# Altium schematic sheet Peripherals.SchDoc(Peripherals)
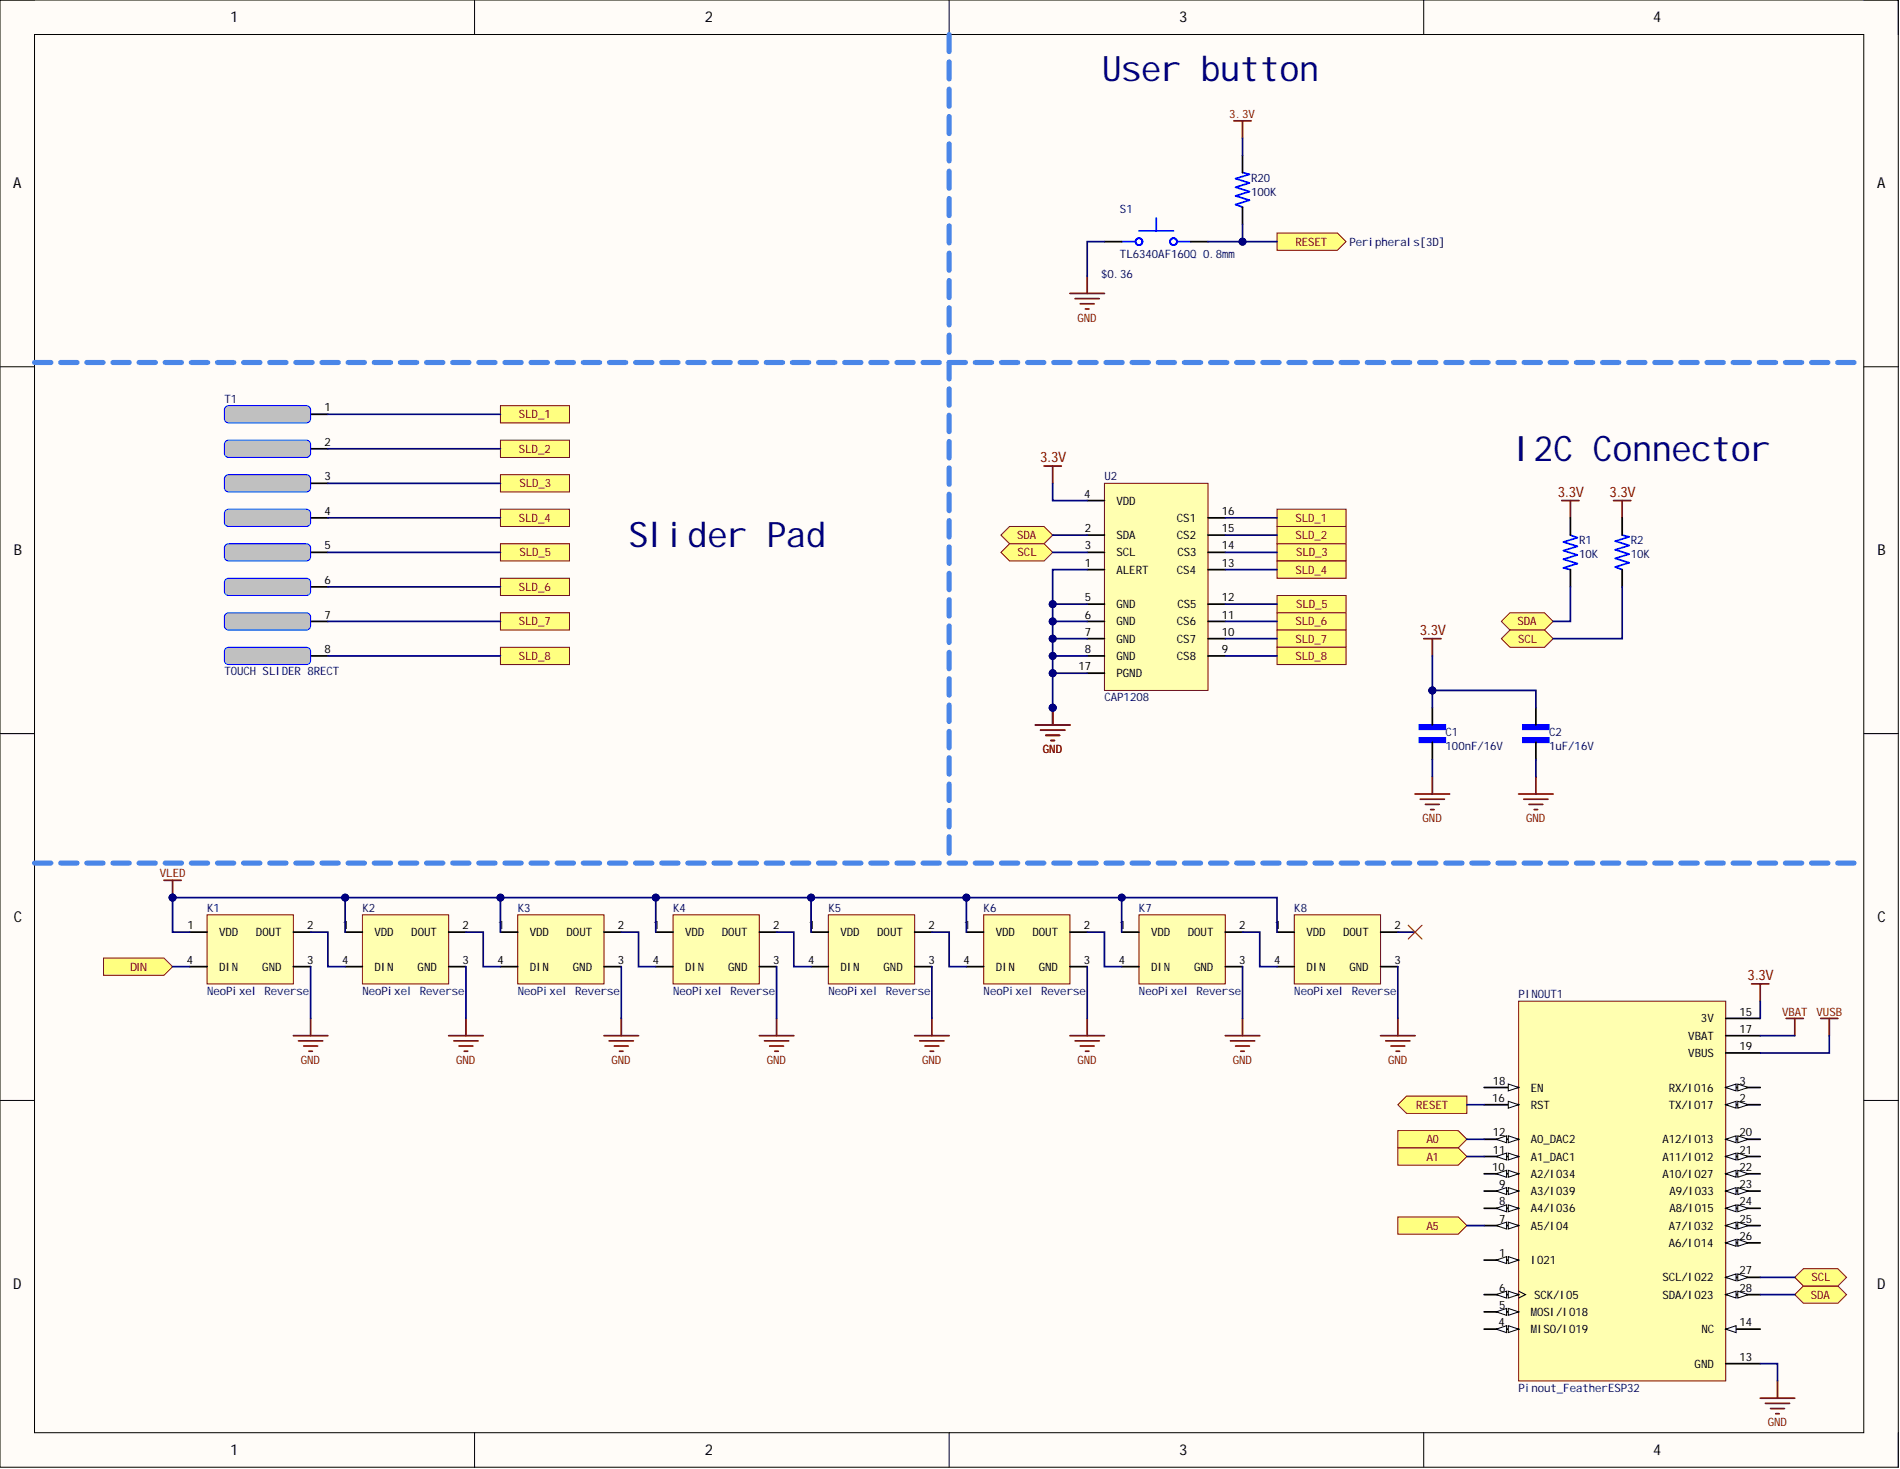
[diagram p0: Peripherals.SchDoc]

## Parts
C1  100nF/16V  [C0603]
C2  1uF/16V  [C0603]
K1  NeoPixel Reverse  [SK6812-E]
K2  NeoPixel Reverse  [SK6812-E]
K3  NeoPixel Reverse  [SK6812-E]
K4  NeoPixel Reverse  [SK6812-E]
K5  NeoPixel Reverse  [SK6812-E]
K6  NeoPixel Reverse  [SK6812-E]
K7  NeoPixel Reverse  [SK6812-E]
K8  NeoPixel Reverse  [SK6812-E]
PINOUT1  Pinout_FeatherESP32  [Pinout_FeatherESP32]
R1  10K  [R0603]
R2  10K  [R0603]
R20  100K  [R0603]
S1  TL6340AF160Q 0.8mm  Parameter 1: $0.36  [TL6340AF160Q]
T1  TOUCH SLIDER 8RECT  [TOUCH SLIDER 8-RECT KEPOUT]  (no BOM)
U2  CAP1208  [QFN16]

## Ports
A0, A1, A5, DIN, RESET, SCL, SDA, SLD_1, SLD_2, SLD_3, SLD_4, SLD_5, SLD_6, SLD_7, SLD_8

## Nets
3.3V: C1.1 C2.1 PINOUT1.15(3V) R1.1 R2.2 R20.2 U2.4(VDD)
GND: C1.2 C2.2 K1.3(GND) K2.3(GND) K3.3(GND) K4.3(GND) K5.3(GND) K6.3(GND) K7.3(GND) K8.3(GND) PINOUT1.13(GND) S1.2 U2.1(ALERT) U2.5(GND) U2.6(GND) U2.7(GND) U2.8(GND) U2.17(PGND)
VBAT: PINOUT1.17(VBAT)
VLED: K1.1(VDD) K2.1(VDD) K3.1(VDD) K4.1(VDD) K5.1(VDD) K6.1(VDD) K7.1(VDD) K8.1(VDD)
VUSB: PINOUT1.19(VBUS)
A0: PINOUT1.12(A0_DAC2) ; port A0
A1: PINOUT1.11(A1_DAC1) ; port A1
A5: PINOUT1.7(A5/IO4) ; port A5
DIN: K1.4(DIN) ; port DIN
RESET: PINOUT1.16(RST) R20.1 S1.1 ; port RESET
SCL: PINOUT1.27(SCL/IO22) R2.1 U2.3(SCL) ; port SCL
SDA: PINOUT1.28(SDA/IO23) R1.2 U2.2(SDA) ; port SDA
SLD_1: T1.1 U2.16(CS1) ; port SLD_1
SLD_2: T1.2 U2.15(CS2) ; port SLD_2
SLD_3: T1.3 U2.14(CS3) ; port SLD_3
SLD_4: T1.4 U2.13(CS4) ; port SLD_4
SLD_5: T1.5 U2.12(CS5) ; port SLD_5
SLD_6: T1.6 U2.11(CS6) ; port SLD_6
SLD_7: T1.7 U2.10(CS7) ; port SLD_7
SLD_8: T1.8 U2.9(CS8) ; port SLD_8
NetK1_2: K1.2(DOUT) K2.4(DIN)
NetK2_2: K2.2(DOUT) K3.4(DIN)
NetK3_2: K3.2(DOUT) K4.4(DIN)
NetK4_2: K4.2(DOUT) K5.4(DIN)
NetK5_2: K5.2(DOUT) K6.4(DIN)
NetK6_2: K6.2(DOUT) K7.4(DIN)
NetK7_2: K7.2(DOUT) K8.4(DIN)

Not connected: K8 2(DOUT); PINOUT1 1(IO21) 2(TX/IO17) 3(RX/IO16) 4(MISO/IO19) 5(MOSI/IO18) 6(SCK/IO5) 8(A4/IO36) 9(A3/IO39) 10(A2/IO34) 14(NC) 18(EN) 20(A12/IO13) 21(A11/IO12) 22(A10/IO27) 23(A9/IO33) 24(A8/IO15) 25(A7/IO32) 26(A6/IO14)

## Library data of the parts
C1 = C0603 100nF/16V: MLCC 0603 100nF 16V 10% X5R; Manufacturer 1: Kyocera AVX; Manufacturer Part Number 1: 0603YD104KAT2A; Capacitance: 100nF; Case Code (Imperial): 0603; Case Code (Metric): 1608; Case/Package: 0603; Category: Ceramic Capacitors; Component Kind: Standard; Depth: 0.81mm; Dielectric: X5R; Height: 0.9mm; Lead Free: Lead Free; Length: 1.6mm; Library Name: Capacitors.SchLib; Max Operating Temperature: 85°C; Min Operating Temperature: -55°C; Mount: Surface Mount; Number of Pins: 2; Package Quantity: 4000; Packaging: Tape and Reel; Pricing 1: 1=0.26000, 10=0.11400, 50=0.11400, 100=0.09700, 1000=0.05900, 10000=0.04800 (USD); RoHS: Yes; Stock 1: 3315; Supplier 1: Mouser; Supplier Part Number 1: 581-0603YD104KAT2A; Termination: SMD/SMT; Thickness: 0.035inch; Tolerance: 10%; Voltage Rating: 16V; Voltage Rating (DC): 16V; Width: 0.81mm
C2 = C0603 1uF/16V: MLCC 0603 1uF 16V 10% X5R; Manufacturer 1: Yageo; Manufacturer Part Number 1: CC0603KRX5R7BB105; Dissipation Factor: 7%; Capacitance: 1uF; Case Code (Imperial): 0603; Case Code (Metric): 1608; Case/Package: 0603; Category: Ceramic Capacitors; Component Kind: Standard; Construction: Flat; Depth: 0.8mm; Dielectric: X5R; Halogen Free: Halogen Free; Height: 0.8mm; Lead Free: Lead Free; Length: 1.6mm; Library Name: Capacitors.SchLib; Max Operating Temperature: 85°C; Min Operating Temperature: -55°C; Mount: Surface Mount; Number of Pins: 2; Package Quantity: 4000; Packaging: Tape and Reel; Pricing 1: 1=0.17000, 10=0.09100, 50=0.09100, 100=0.04100, 1000=0.03400, 10000=0.02100 (USD); Radiation Hardening: No; REACH SVHC: Unknown; Resistor Type: Ceramic; RoHS: Yes; RoHS Compliant: Yes; Series: CC; Stock 1: 726921; Supplier 1: Mouser; Supplier Part Number 1: 603-CC603KRX5R7BB105; Termination: SMD/SMT; Thickness: 0.035inch; Tolerance: 10%; Voltage Rating: 16V; Voltage Rating (DC): 16V; Width: 0.8mm
K1-K8 = SK6812-E: NeoPixel Reverse Mount RGB LEDs - SK6812-E; Manufacturer 1: Adafruit Industries; Manufacturer Part Number 1: 4960; Component Kind: Standard; Library Name: LED.SchLib; Pricing 1: 1=2.95, 10=2.95, 50=2.95, 100=2.95, 1000=2.95, 10000=2.95 (USD); RoHS: No; Supplier 1: Mouser; Supplier 2: LCSC; Supplier Part Number 1: 485-4960; Supplier Part Number 2: C5149201
PINOUT1 = Pinout_FeatherESP32: Breadboard, Prepunched Insulating Non-Plated Through Hole (NPTH) 0.100 (2.54mm); MF: Keystone Electronics; MP: 3405; Arrow_Electronics-Purchase-URL: https://snapeda.com/shop?store=Arrow+Electronics&id=1260737; Availability: Warning; Component Kind: Standard; Mouser-Purchase-URL: https://snapeda.com/shop?store=Mouser&id=1260737; Package: None; Price: None; SnapEDA_Link: https://www.snapeda.com/parts/3405/Keystone%20Electronics/view-part/1260737/?ref=snap; Library Name: SlidersTouch.SchLib
R1 R2 = R0603 10K: SMD Chip Resistor, 10 kOhm, ± 1%, 100 mW, 0603 [1608 Metric], Thick Film, Anti-Sulfur; Manufacturer 1: Yageo; Manufacturer Part Number 1: AF0603FR-0710KL; Max Power Dissipation: 100mW; Temperature Coefficient: 100ppm/°C; Value: 10kR; Voltage Rating: 50V; Case Code (Imperial): 0603; Case Code (Metric): 1608; Case/Package: 0603; Category: Chip SMD Resistors; Component Kind: Standard; Features: Moisture Resistant,Anti-Sulfur; Height: 0.022inch; Lead Free: 5697LeadFree; Length: 0.063inch; Library Name: Resistors.SchLib; Max Operating Temperature: 155°C; Min Operating Temperature: -55°C; Number of Terminations: 2; Package Quantity: 1; Packaging: Tape and Reel; Power Rating: 100mW; Pricing 3: 5000=0.00223, 10000=0.00219, 20000=0.00213 (USD); RoHS: Yes; RoHS Compliant: Yes; Series: AF; Stock 3: 5000; Supplier 1: Mouser; Supplier 2: Mouser; Supplier Part Number 1: 603-AF0603FR-0710KL; Supplier Part Number 2: 667-ERJ-3EKF1002V; Termination: SMD/SMT; Tolerance: 1%; Width: 0.031inch
R20 = R0603 100K: RES 0603 100K OHM 1% 1/10W; Manufacturer 1: Yageo; Manufacturer Part Number 1: RC0603FR-07100KL; Power Rating: 100mW; Resistance: 100kR; Temperature Coefficient: 100ppm/°C; Voltage Rating: 50V; Working Voltage: 75V; Case Code (Imperial): 0603; Case Code (Metric): 1608; Case/Package: 0603; Category: Chip SMD Resistors; Component Kind: Standard; Depth: 0.8mm; Height: 0.45mm; Lead Free: Lead Free; Length: 1.6mm; Library Name: Resistors.SchLib; Max Operating Temperature: 155°C; Military Standard: Not; Min Operating Temperature: -55°C; Number of Pins: 2; Number of Terminations: 2; Packaging: Tape and Reel; Pricing 1: 1=0.10000, 10=0.02100, 100=0.00900, 1000=0.00400, 5000=0.00300, 10000=0.00200 (USD); REACH SVHC: No SVHC; Resistor Type: Thick Film; RoHS: Yes; Stock 1: 4536807; Supplier 1: Mouser; Supplier Part Number 1: 603-RC0603FR-07100KL; Termination: SMD/SMT; Tolerance: 1%; Voltage Rating (DC): 50V; Width: 0.8mm
S1 = TL6340AF160Q 0.8mm: TACTILE SWITCH, SPST, 0.02A/12VDC, SMD; Product Range: TL6340 Series; Actuator Orientation: Side Actuated; Switch...; Manufacturer: E-Switch; Category: Tactile Switches; Component Kind: Standard; Library Name: Switches.SchLib; Manufacturer Part Number: TL6340AF160Q; Pricing 1: 1=0.51, 10=0.478, 50=0.478, 100=0.46, 1000=0.36, 10000=0.255 (USD); RoHS: Yes; Stock 1: 2636; Supplier 1: Mouser; Supplier 2: LCSC; Supplier Part Number 1: 612-TL6340AF160QG; Supplier Part Number 2: C4944967
T1 = TOUCH SLIDER 8RECT: 8-Segment touch slider; Library Name: SlidersTouch.SchLib
U2 = CAP1208: CAP1208 Series 8-Channel Capacitive Touch Surface Mount Proximity - QFN-16; Manufacturer: Microchip; Manufacturer Part Number: CAP1208-1-A4-TR; Interface: I2C,SMBus; Max Supply Voltage: 5.5V; Min Supply Voltage: 3V; Number of Channels: 8; Operating Supply Current: 500uA; Operating Supply Voltage: 5V; Case/Package: QFN; Category: Touch Screen Controllers; Component Kind: Standard; ComponentLink1Description: https://datasheet.ciiva.com/28419/1772686-28419124.pdf?src-supplier=Element14; ComponentLink1URL: https://datasheet.ciiva.com/28419/1772686-28419124.pdf?src-supplier=Element14; ComponentLink2Description: https://datasheet.ciiva.com/29355/filehandler-aspxddocname-en566275-29355120.pdf?src-supplier=Digi-Key; ComponentLink2URL: https://datasheet.ciiva.com/29355/filehandler-aspxddocname-en566275-29355120.pdf?src-supplier=Digi-Key; ComponentLink3Description: https://datasheet.ciiva.com/24141/00001570a-278059-24141215.pdf?src-supplier=Mouser; ComponentLink3URL: https://datasheet.ciiva.com/24141/00001570a-278059-24141215.pdf?src-supplier=Mouser; ComponentLink4Description: https://datasheet.ciiva.com/29895/00001570a-29895445.pdf?src-supplier=Avnet; ComponentLink4URL: https://datasheet.ciiva.com/29895/00001570a-29895445.pdf?src-supplier=Avnet; ComponentLink5Description: https://datasheet.ciiva.com/39123/594000001570b-39123436.pdf?src-supplier=Onlinecomponents.com; ComponentLink5URL: https://datasheet.ciiva.com/39123/594000001570b-39123436.pdf?src-supplier=Onlinecomponents.com; Length: 3mm; Max Operating Temperature: 125°C; Min Operating Temperature: -40°C; Mounting Technology: Surface Mount; Number of Inputs: 8; Package Quantity: 5000; Packaging: Tape and Reel; Pins: 16; Pricing 1: 1=1.04, 10=1.04, 50=1, 100=0.93, 1000=0.93, 10000=0.929 (USD); Reach SVHC Compliant: No; RoHS: Yes; RoHS Compliant: Yes; Series: -; Stock 1: 19733; Supplier 1: Mouser; Supplier 2: Digi-Key; Supplier Part Number 1: 579-CAP1208-1-A4-TR; Supplier Part Number 2: CAP1208-1-A4-TRCT-ND; Supply Current: 500uA; Termination: SMD/SMT; Touchscreen: Capacitive; Width: 3mm; Library Name: IC Comms.SchLib
